# Altium schematic sheet Slave.SchDoc(Slave)
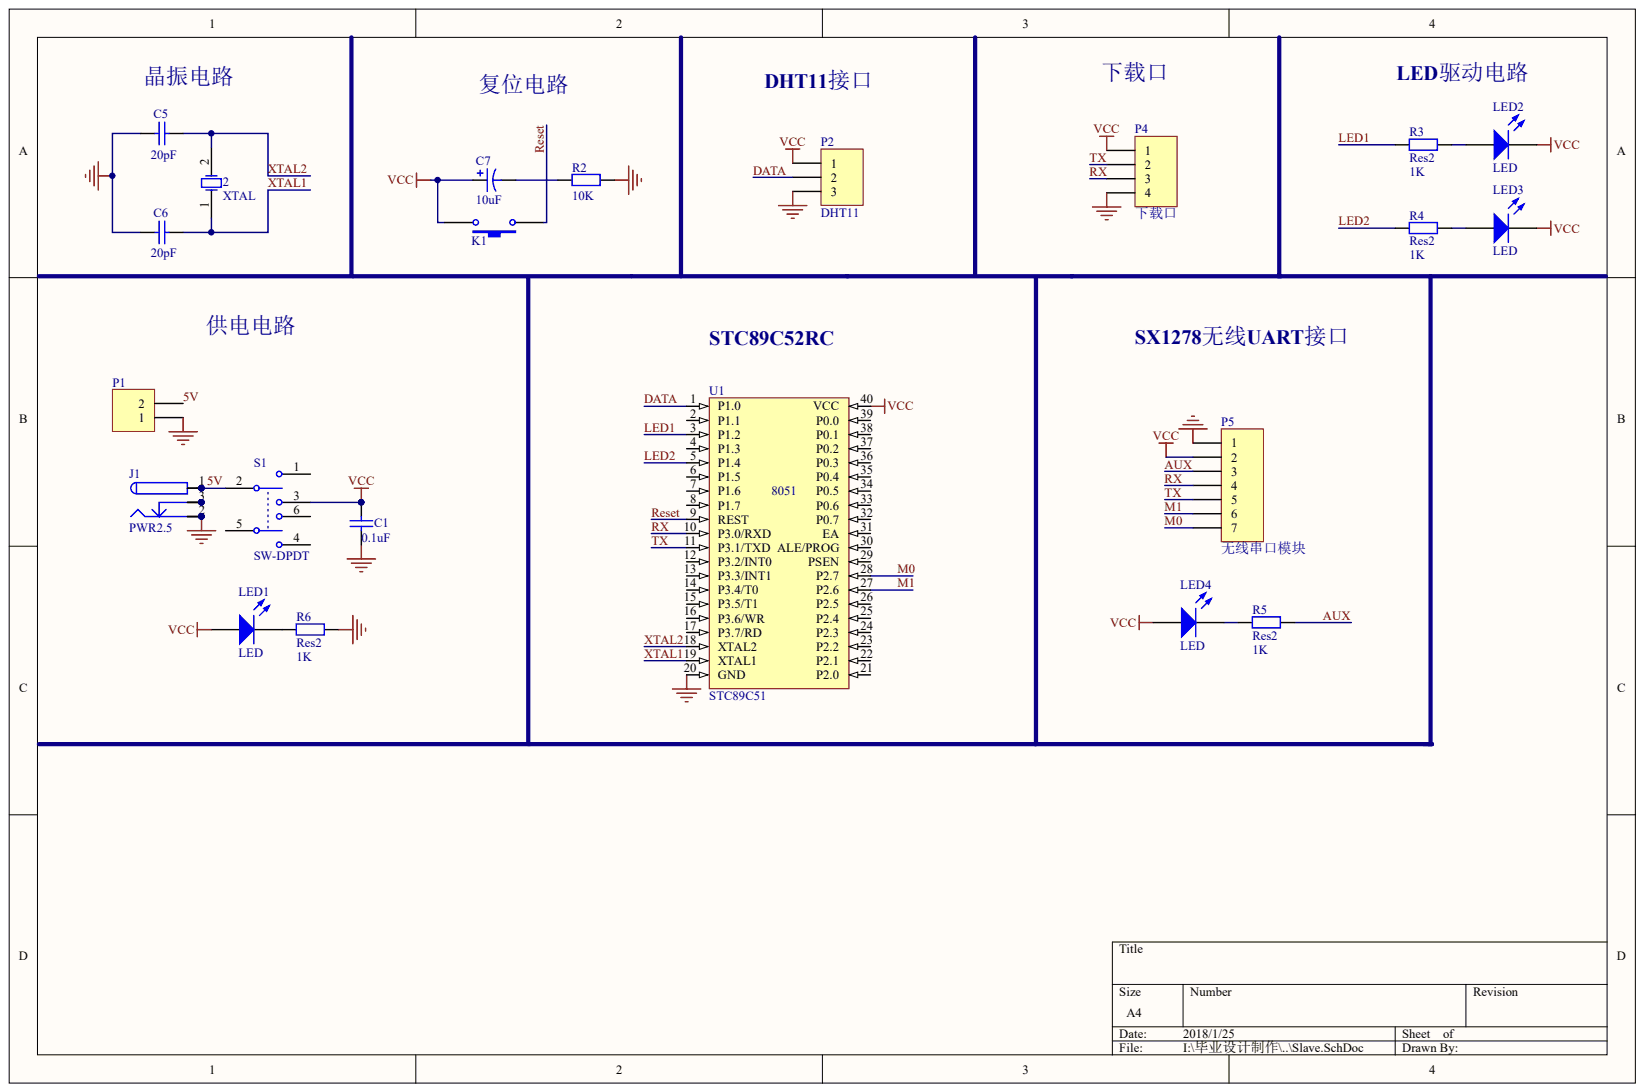
[diagram p0: Slave.SchDoc]

## Parts
2  XTAL
C1  value 0.1uF  (hidden comment: Cap)
C5  value 20pF  (hidden comment: Cap)
C6  value 20pF  (hidden comment: Cap)
C7  value 10uF  (hidden comment: Cap Pol1)
J1  PWR2.5
K1  四脚按键  (value not drawn)
LED1  LED
LED2  LED
LED3  LED
LED4  LED
P1  (no value)
P2  DHT11
P4  下载口
P5  无线串口模块
R2  value 10K  (hidden comment: Res2)
R3  Res2  value 1K
R4  Res2  value 1K
R5  Res2  value 1K
R6  Res2  value 1K
S1  SW-DPDT
U1  STC89C51

## Nets
GND: C1.1 C5.1 C6.1 J1.2 J1.2A J1.2B P1.1 P2.3 P4.4 P5.1 R2.2 R6.2 U1.20(GND)
VCC: C1.2 C7.1 K1.2 LED1.1 LED2.2 LED3.2 LED4.1 P2.1 P4.1 P5.2 S1.3 U1.40(VCC)
5V: J1.1 J1.1A J1.1B P1.2 S1.2
AUX: P5.3 R5.2
DATA: P2.2 U1.1(P1.0)
LED1: R3.1 U1.3(P1.2)
LED2: R4.1 U1.5(P1.4)
M0: P5.7 U1.28(P2.7)
M1: P5.6 U1.27(P2.6)
RESET: K1.1 U1.9(REST)
RX: P4.3 P5.4 U1.10(P3.0/RXD)
TX: P4.2 P5.5 U1.11(P3.1/TXD)
XTAL1: 2.1 C6.2 U1.19(XTAL1)
XTAL2: 2.2 C5.2 U1.18(XTAL2)
NetC7_2: C7.2 R2.1
NetJ1_3: J1.3 J1.3A J1.3B
NetLED1_2: LED1.2 R6.1
NetLED2_1: LED2.1 R3.2
NetLED3_1: LED3.1 R4.2
NetLED4_2: LED4.2 R5.1

Not connected: S1 1 4 5 6; U1 2(P1.1) 4(P1.3) 6(P1.5) 7(P1.6) 8(P1.7) 12(P3.2/INT0) 13(P3.3/INT1) 14(P3.4/T0) 15(P3.5/T1) 16(P3.6/WR) 17(P3.7/RD) 21(P2.0) 22(P2.1) 23(P2.2) 24(P2.3) 25(P2.4) 26(P2.5) 29(PSEN) 30(ALE/PROG) 31(EA) 32(P0.7) 33(P0.6) 34(P0.5) 35(P0.4) 36(P0.3) 37(P0.2) 38(P0.1) 39(P0.0)

## Library data of the parts
2 C1 C5-C7 J1 K1 LED1-LED4 P1 P2 P4 P5 R2-R6 S1 U1 = (no symbol name)
  2: Published: 8-Jun-2000; LatestRevisionDate: 17-Jul-2002; LatestRevisionNote: Re-released for DXP Platform.; PackageReference: R38; PackageDescription: Crystal, Thru-Hole; 2 Leads; Body 3.1 x 8.2 mm (Dia.xH)
  C1 C5 C6: Published: 8-Jun-2000; LatestRevisionDate: 17-Jul-2002; LatestRevisionNote: Re-released for DXP Platform.; PackageReference: RAD-0.3; PackageDescription: Radial Cap, Thru-Hole; 2 Leads; 0.3 in Pin Spacing
  C7: Published: 8-Jun-2000; LatestRevisionDate: 17-Jul-2002; LatestRevisionNote: Re-released for DXP Platform.; PackageReference: RB7.6-15; PackageDescription: Polarized Capacitor (Radial); 2 Leads
  J1: Published: 17-Dec-2003; DatasheetDocument: 09-May-2002; ComponentLink1Description: Manufacturer Link; ComponentLink1URL: http://domino.kycon.com/internet/Products.html
  P1 P2 P4 P5: LatestRevisionDate: 17-Jul-2002; LatestRevisionNote: Re-released for DXP Platform.
  R2-R6: Published: 8-Jun-2000; LatestRevisionDate: 17-Jul-2002; LatestRevisionNote: Re-released for DXP Platform.; PackageReference: AXIAL-0.4; PackageDescription: Axial Device, Thru-Hole; 2 Leads; 0.4 in Pin Spacing
  S1: Published: 24-Mar-1999; LatestRevisionDate: 17-Jul-2002; LatestRevisionNote: Re-released for DXP Platform.; PackageReference: DPDT-6
  2 C1 C5-C7 J1 P1 P2 P4 P5 R2-R6 S1: Publisher: Altium Limited
